# Altium schematic sheet [2] MCU.SchDoc
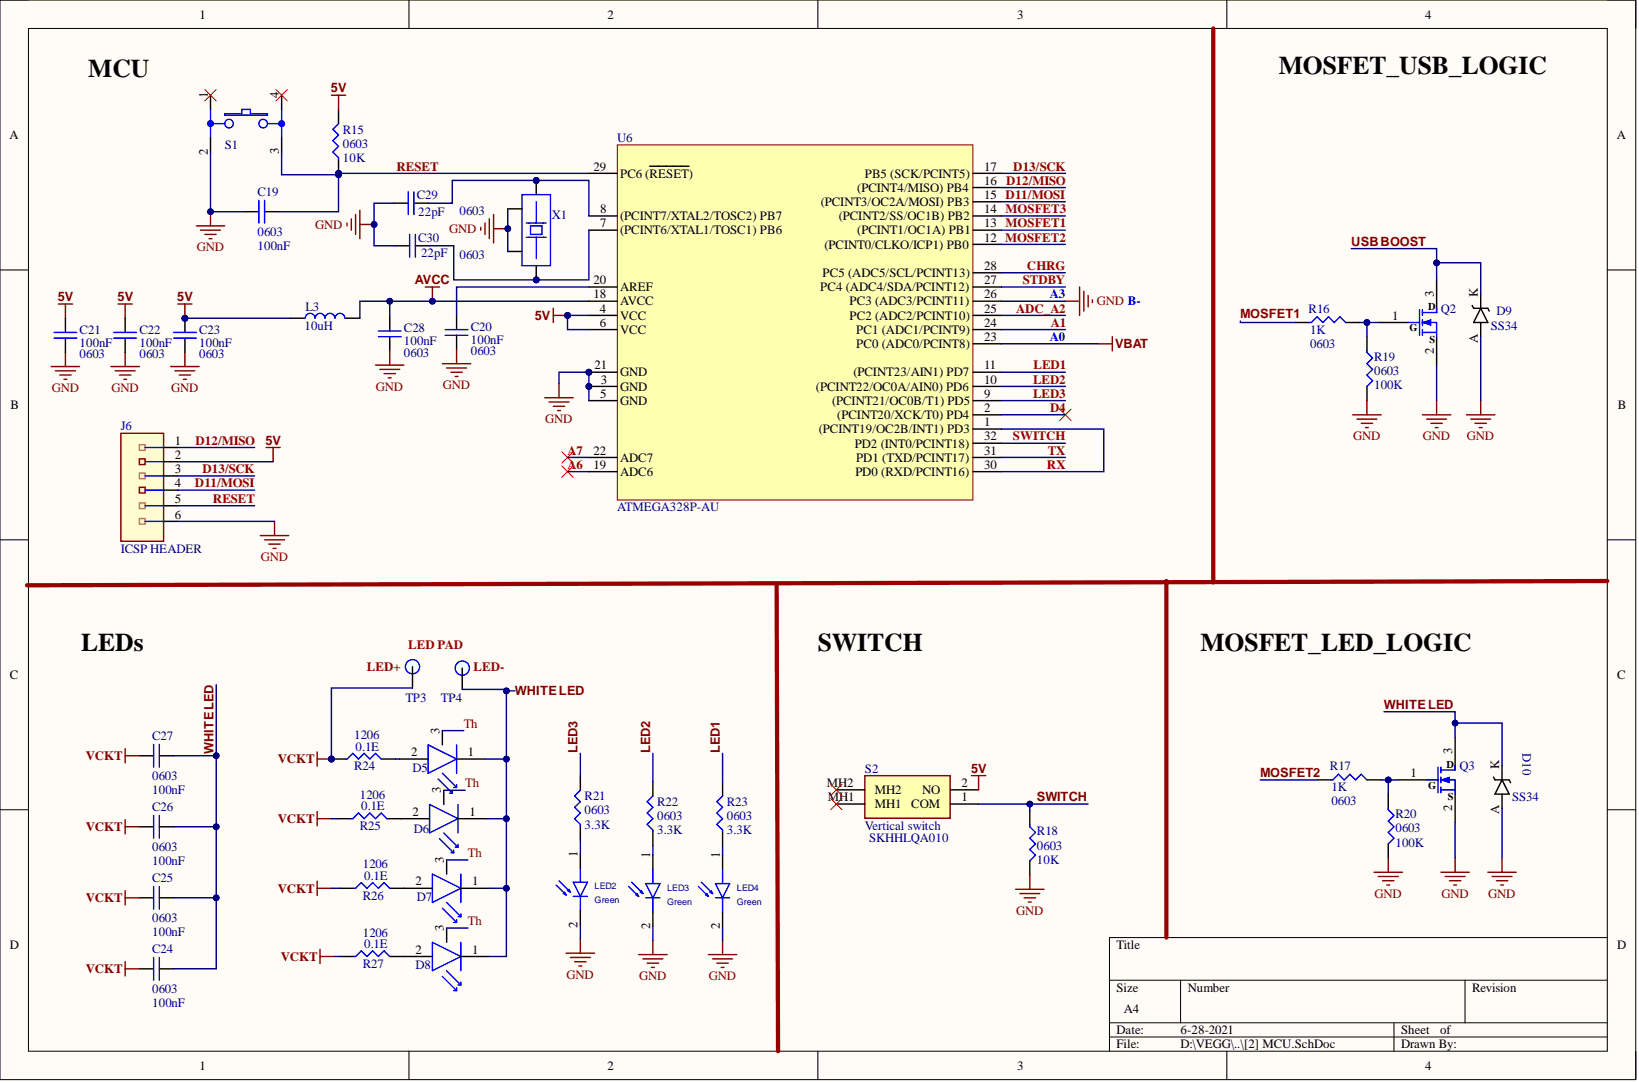
[diagram p0: [2] MCU.SchDoc]

## Parts
C19  value 100nF  Case/Package: 0603  [CAPC0603]  (hidden comment: Cap)
C20  value 100nF  Case/Package: 0603  [RESC0603]  (hidden comment: Cap)
C21  value 100nF  Case/Package: 0603  [RESC0603]  (hidden comment: Cap)
C22  value 100nF  Case/Package: 0603  [RESC0603]  (hidden comment: Cap)
C23  value 100nF  Case/Package: 0603  [CAPC0603]  (hidden comment: Cap)
C24  value 100nF  Case/Package: 0603  [CAPC0603]  (hidden comment: Cap)
C25  value 100nF  Case/Package: 0603  [CAPC0603]  (hidden comment: Cap)
C26  value 100nF  Case/Package: 0603  [CAPC0603]  (hidden comment: Cap)
C27  Case/Package: 0603  [CAPC0603]  (hidden comment: Cap)
C28  value 100nF  Case/Package: 0603  [CAPC0603]  (hidden comment: Cap)
C29  value 22pF  [CAPC0603]  (hidden comment: Cap)
C30  value 22pF  [CAPC0603]  (hidden comment: Cap)
D5  UF4007  [1W POWER LED]  (value not drawn)
D6  UF4007  [1W POWER LED]  (value not drawn)
D7  UF4007  [1W POWER LED]  (value not drawn)
D8  UF4007  [1W POWER LED]  (value not drawn)
D9  SS34  [SMA]
D10  SS34  [SMA]
J6  ICSP HEADER  [Header_2x3_R]
L3  value 10uH  [l0805]  (hidden comment: Inductor)
LED2  Green  [LED-1 - HORIZONTAL_2]
LED3  Green  [LED-1 - HORIZONTAL_2]
LED4  Green  [LED-1 - HORIZONTAL_2]
Q2  MOSFET-N Si2302CDS  [SOT95P240X115-3N]  (value not drawn)
Q3  MOSFET-N Si2302CDS  [SOT95P240X115-3N]  (value not drawn)
R15  value 10K  Case/Package: 0603  [RESC0603]  (hidden comment: Res)
R16  value 1K  Case/Package: 0603  [RESC0603]  (hidden comment: Res)
R17  value 1K  Case/Package: 0603  [RESC0603]  (hidden comment: Res)
R18  value 10K  Case/Package: 0603  [RESC0603]  (hidden comment: Res)
R19  value 100K  Case/Package: 0603  [RESC0603]  (hidden comment: Res)
R20  value 100K  Case/Package: 0603  [RESC0603]  (hidden comment: Res)
R21  value 3.3K  Case/Package: 0603  [RESC0603]  (hidden comment: Res)
R22  value 3.3K  Case/Package: 0603  [RESC0603]  (hidden comment: Res)
R23  value 3.3K  Case/Package: 0603  [RESC0603]  (hidden comment: Res)
R24  value 0.1E  Case/Package: 1206  [resc1206]  (hidden comment: Res)
R25  value 0.1E  Case/Package: 1206  [resc1206]  (hidden comment: Res)
R26  value 0.1E  Case/Package: 1206  [resc1206]  (hidden comment: Res)
R27  value 0.1E  Case/Package: 1206  [resc1206]  (hidden comment: Res)
S1  SW_TC_SPST_PUSH  [Tactile Switch, 4.5x4.5x5mm]  (value not drawn)
S2  Vertical switch  Manufacturer Part Number: SKHHLQA010  [Vertical switch]
TP3  TP  [TP-1]  (no BOM)
TP4  TP  [TP-1]  (no BOM)
U6  ATMEGA328P-AU  [TQFP-32_7x7x08P]
X1  XXDPBISANF-16.000000  [SMD-2520]  (value not drawn)

## Nets
5V: C21.1 C22.1 C23.1 J6.2 L3.1 R15.2 S2.2(NO) U6.4(VCC) U6.6(VCC)
AVCC: C28.1 L3.2 U6.18(AVCC)
GND: C19.1 C20.2 C21.2 C22.2 C23.2 C28.2 C29.2 C30.1 D9.A D10.A J6.6 LED2.2 LED3.2 LED4.2 Q2.2 Q3.2 R18.2 R19.2 R20.2 S1.2 U6.3(GND) U6.5(GND) U6.21(GND) U6.26(PC3_(ADC3/PCINT11)) X1.2 X1.4
VBAT: U6.23(PC0_(ADC0/PCINT8))
VCKT: C24.1 C25.1 C26.1 C27.1 R24.1 R25.1 R26.1 R27.1 TP3.1
A1: U6.24(PC1_(ADC1/PCINT9))
A6: U6.19(ADC6)
A7: U6.22(ADC7)
ADC_A2: U6.25(PC2_(ADC2/PCINT10))
CHRG: U6.28(PC5_(ADC5/SCL/PCINT13))
D4: U6.2((PCINT20/XCK/T0)_PD4)
D11/MOSI: J6.4 U6.15((PCINT3/OC2A/MOSI)_PB3)
D12/MISO: J6.1 U6.16((PCINT4/MISO)_PB4)
D13/SCK: J6.3 U6.17(PB5_(SCK/PCINT5))
LED1: R23.2 U6.11((PCINT23/AIN1)_PD7)
LED2: R22.2 U6.10((PCINT22/OC0A/AIN0)_PD6)
LED3: R21.2 U6.9((PCINT21/OC0B/T1)_PD5)
MOSFET1: R16.1 U6.13((PCINT1/OC1A)_PB1)
MOSFET2: R17.1 U6.12((PCINT0/CLKO/ICP1)_PB0)
MOSFET3: U6.14((PCINT2/SS/OC1B)_PB2)
RESET: C19.2 J6.5 R15.1 S1.3 U6.29(PC6_(RESET))
RX: U6.1((PCINT19/OC2B/INT1)_PD3) U6.30(PD0_(RXD/PCINT16))
STDBY: U6.27(PC4_(ADC4/SDA/PCINT12))
SWITCH: R18.1 S2.1(COM) U6.32(PD2_(INT0/PCINT18))
Th: D5.3 D6.3 D7.3 D8.3
TX: U6.31(PD1_(TXD/PCINT17))
USB BOOST: D9.K Q2.3
WHITE LED: C24.2 C25.2 C26.2 C27.2 D5.1 D6.1 D7.1 D8.1 D10.K Q3.3 TP4.1
NetC20_1: C20.1 U6.20(AREF)
NetC29_1: C29.1 U6.8((PCINT7/XTAL2/TOSC2)_PB7) X1.1
NetC30_2: C30.2 U6.7((PCINT6/XTAL1/TOSC1)_PB6) X1.3
NetD5_2: D5.2 R24.2
NetD6_2: D6.2 R25.2
NetD7_2: D7.2 R26.2
NetD8_2: D8.2 R27.2
NetLED2_1: LED2.1 R21.1
NetLED3_1: LED3.1 R22.1
NetLED4_1: LED4.1 R23.1
NetQ2_1: Q2.1 R16.2 R19.1
NetQ3_1: Q3.1 R17.2 R20.1

Not connected: S1 1 4; S2 MH1 MH2

## Library data of the parts
C19-C28 = Cap: Ceramic Capacitor,100nF,10V,0603; Manufacturer Part Number: CC0603KRX7R6BB104; Component Kind: Standard; ComponentLink1Description: http://www.farnell.com/datasheets/2739827.pdf?src-supplier=Element14; ComponentLink1URL: http://www.farnell.com/datasheets/2739827.pdf?src-supplier=Element14; ComponentLink2Description: http://www.farnell.com/datasheets/40889.pdf?src-supplier=Element14; ComponentLink2URL: http://www.farnell.com/datasheets/40889.pdf?src-supplier=Element14; Library Name: CUSTOM TNY290PG.SchLib; Signal Integrity: Cap Pol; Simulation: CAP
  C27: Value: 100nF
C29 C30 = Cap: Ceramic Capacitor,22pF,50V,0603; Manufacturer Part Number: FN18N220J500PSG; Case/Package: 0603; Component Kind: Standard; ComponentLink1Description: http://www.farnell.com/datasheets/2739827.pdf?src-supplier=Element14; ComponentLink1URL: http://www.farnell.com/datasheets/2739827.pdf?src-supplier=Element14; ComponentLink2Description: http://www.farnell.com/datasheets/40889.pdf?src-supplier=Element14; ComponentLink2URL: http://www.farnell.com/datasheets/40889.pdf?src-supplier=Element14; Library Name: CUSTOM TNY290PG.SchLib; Signal Integrity: Cap Pol; Simulation: CAP
D5-D8 = UF4007: Led Zpower Warm White 3000K 2SMD; Manufacturer 1: Seoul Semiconductor; Manufacturer Part Number: N42180-06-S2; Forward Voltage: 3.1V; Luminous Flux: 65lm; Max Current Rating: 800mA; Test Current: 350mA; Thermal Resistance of Package: 8.5°C/W; Viewing Angle: 126°; Case/Package: SMD/SMT; Category: LEDs; Color: White; Component Kind: Standard; ComponentLink1Description: https://datasheet.ciiva.com/29560/x42180-070-29560315.pdf?src-supplier=Digi-Key; ComponentLink1URL: https://datasheet.ciiva.com/29560/x42180-070-29560315.pdf?src-supplier=Digi-Key; ComponentLink2Description: https://datasheet.ciiva.com/29560/x42180-070-29560316.pdf?src-supplier=Digi-Key; ComponentLink2URL: https://datasheet.ciiva.com/29560/x42180-070-29560316.pdf?src-supplier=Digi-Key; Correlated Color Temperature (CCT): 3000K; Library Name: CUSTOM TNY290PG.SchLib; Mount: Surface Mount; Package Quantity: 500; Packaging: Tape and Reel; Pricing 1: 1=2.54000, 10=2.28800, 100=2.14400 (USD); RoHS: Yes; Stock 1: 29310; Supplier 1: Digi-Key; Supplier Part Number 1: N42180-06-S2CT-ND
D9 D10 = SS34: 40V 3A 550mV @ 3A SMA Schottky Barrier Diodes (SBD) RoHS; Case/Package: SMA; Component Kind: Standard; ComponentLink1Description: https://datasheet.ciiva.com/30478/0900766b812769b5-30478676.pdf?src-supplier=RSComponents; ComponentLink1URL: https://datasheet.ciiva.com/30478/0900766b812769b5-30478676.pdf?src-supplier=RSComponents; ComponentLink2Description: https://datasheet.ciiva.com/25958/esd9x3-3st5g-d-25958458.pdf?src-supplier=Avnet+Europe; ComponentLink2URL: https://datasheet.ciiva.com/25958/esd9x3-3st5g-d-25958458.pdf?src-supplier=Avnet+Europe; ComponentLink3Description: https://datasheet.ciiva.com/1219/1748783-1219324.pdf?src-supplier=Newark; ComponentLink3URL: https://datasheet.ciiva.com/1219/1748783-1219324.pdf?src-supplier=Newark; ComponentLink4Description: https://datasheet.ciiva.com/3304/esd9x3-3st5g-d-3304012.pdf?src-supplier=Avnet; ComponentLink4URL: https://datasheet.ciiva.com/3304/esd9x3-3st5g-d-3304012.pdf?src-supplier=Avnet; ComponentLink5Description: https://datasheet.ciiva.com/339/esd9x3-3st5g-d-339709.pdf?src-supplier=Digi-Key; ComponentLink5URL: https://datasheet.ciiva.com/339/esd9x3-3st5g-d-339709.pdf?src-supplier=Digi-Key; ComponentLink6Description: https://datasheet.ciiva.com/16215/esd9x3-3st5g-d-16215149.pdf?src-supplier=Future+Electronics; ComponentLink6URL: https://datasheet.ciiva.com/16215/esd9x3-3st5g-d-16215149.pdf?src-supplier=Future+Electronics; ComponentLink7Description: https://datasheet.ciiva.com/339/esd9x3-3st5g-d-339710.pdf?src-supplier=Digi-Key; ComponentLink7URL: https://datasheet.ciiva.com/339/esd9x3-3st5g-d-339710.pdf?src-supplier=Digi-Key; ComponentLink8Description: https://datasheet.ciiva.com/339/esd9x3-3st5g-d-339711.pdf?src-supplier=Digi-Key; ComponentLink8URL: https://datasheet.ciiva.com/339/esd9x3-3st5g-d-339711.pdf?src-supplier=Digi-Key; Library Name: CUSTOM TNY290PG.SchLib; Manufacturer Part Number: SS34; Value: SS34
J6 = HEADER 6: 6P_2 Row(2x3) P=2.54mm Straight Pin Header; Manufacturer Part Number: PZ254V-12-6P; Case/Package: TH 2.54MM; Component Kind: Standard; Library Name: CUSTOM TNY290PG.SchLib
L3 = Inductor: 10uH 100mA 0805 Chip ferrite Inductor RoHS; Manufacturer Part Number: MGFL2012F100MT-LF; Case/Package: 0805; Component Kind: Standard; Library Name: CUSTOM TNY290PG.SchLib
LED2-LED4 = LED2: LED, Green, Untinted, Diffused; Manufacturer Part Number: KP DELPS1.FP-UGVI-34-Z555; Case/Package: TH; Component Kind: Standard; Stock 1: 0; Supplier 1: Mouser; Supplier Part Number 1: 720-KPDELPS1FPA3998
Q2 Q3 = MOSFET-N Si2302CDS: N-Channel 20V 3A 1.25W (Ta) Surface Mount SOT-23; Manufacturer: MCC; Manufacturer Part Number: SI2302-TP; Drain to Source Resistance: 110mR; Gate to Source Voltage (Vgs): 8V; Input Capacitance: 237pF; Number of Elements: 1; Rds On Max: 72mR; Case/Package: SOT-23; Component Kind: Standard; ComponentLink1Description: http://www.vishay.com/docs/68645/si2302cd.pdf?src-supplier=Avnet; ComponentLink1URL: http://www.vishay.com/docs/68645/si2302cd.pdf?src-supplier=Avnet; ComponentLink2Description: http://www.farnell.com/datasheets/1719572.pdf?src-supplier=Element14; ComponentLink2URL: http://www.farnell.com/datasheets/1719572.pdf?src-supplier=Element14; ComponentLink3Description: http://www.vishay.com/docs/68645/si2302cd.pdf?src-supplier=Future+Electronics; ComponentLink3URL: http://www.vishay.com/docs/68645/si2302cd.pdf?src-supplier=Future+Electronics; ComponentLink4Description: http://www.vishay.com/docs/68645/si2302cds.pdf?src-supplier=Digi-Key; ComponentLink4URL: http://www.vishay.com/docs/68645/si2302cds.pdf?src-supplier=Digi-Key; ComponentLink5Description: http://www.mccsemi.com/up_pdf/SI2302(SOT-23).pdf?src-supplier=Digi-Key; ComponentLink5URL: http://www.mccsemi.com/up_pdf/SI2302(SOT-23).pdf?src-supplier=Digi-Key; Continuous Drain Current (ID): 3A; Drain to Source Breakdown Voltage: 20V; Drain to Source Voltage (Vdss): 20V; Lead Free: Lead Free; Library Name: Miscellaneous Devices.SchLib; Max Operating Temperature: 150°C; Max Power Dissipation: 1.25W; Min Operating Temperature: -55°C; Mount: Surface Mount; Number of Pins: 3; Package Quantity: 3000; Packaging: Tape and Reel; Power Dissipation: 1.25W; Pricing 1: 1=0.45, 10=0.386, 100=0.288, 500=0.226, 1000=0.175, 3000=0.145 (USD); Simulation: NMOS; Stock 1: 29970; Supplier 1: Mouser; Supplier Part Number 1: 833-SI2302-TP
R15 R18 = Res: Thick Film Resistor -  10Kohm, 1%, 0603; Manufacturer Part Number: RK73B1JTTD103J; Component Kind: Standard; Library Name: CUSTOM TNY290PG.SchLib
R16 R17 = Res: Thick Film Resistor -  1Kohm, 1%, 0603; Manufacturer Part Number: RS-03K1001FT; Component Kind: Standard; Library Name: CUSTOM TNY290PG.SchLib
R19 R20 = Res: Thick Film Resistor -  100Kohm, 1%, 0603; Manufacturer Part Number: RS-03K1001FT; Component Kind: Standard; Library Name: CUSTOM TNY290PG.SchLib
R21-R23 = Res: Thick Film Resistor -  3.3Kohm, 1%, 0603; Manufacturer Part Number: RS-03K3301FT; Component Kind: Standard; Library Name: CUSTOM TNY290PG.SchLib
R24-R27 = Res: Thick Film Resistor - 0.1ohm, 1%, 0.25W, 1206; Manufacturer Part Number: CR1206FR100P05R; Component Kind: Standard; Library Name: CUSTOM TNY290PG.SchLib
S1 = SW_TC_SPST_PUSH: SPST 50mA @ 12VDC Top Actuated Round Button 160gf 4.5*4.5*3.8 Tactile Switch; Manufacturer Part Number: UK-B0206-G3.8-160-JZ; Case/Package: 4.5X4.5X5mm_SMD; Component Kind: Standard; Library Name: CUSTOM TNY290PG.SchLib
S2 = Vertical switch: SPST 50mA @ 12VDC Side operation Round Button Through Hole Tactile Switches RoHS; Manufacturer: Alps Electric; Contact Resistance: 100mR; Electrical Life: 1000000Cycles; Illumination Type: Illuminated; Max Voltage Rating (DC): 12V; Operating Force: 0.98N; Case/Package: TH; Category: Tactile Switches; Color: Black; Component Kind: Standard; ComponentLink1Description: https://datasheet.ciiva.com/6797/1582312-6797697.pdf?src-supplier=Newark; ComponentLink1URL: https://datasheet.ciiva.com/6797/1582312-6797697.pdf?src-supplier=Newark; ComponentLink2Description: https://datasheet.ciiva.com/25620/0900766b810d5f21-25620205.pdf?src-supplier=RSComponents; ComponentLink2URL: https://datasheet.ciiva.com/25620/0900766b810d5f21-25620205.pdf?src-supplier=RSComponents; ComponentLink3Description: https://datasheet.ciiva.com/26906/getdatasheetpartid-652171-26906222.pdf?src-supplier=Verical; ComponentLink3URL: https://datasheet.ciiva.com/26906/getdatasheetpartid-652171-26906222.pdf?src-supplier=Verical; ComponentLink4Description: https://datasheet.ciiva.com/16156/skhh-16156038.pdf?src-supplier=Onlinecomponents.com; ComponentLink4URL: https://datasheet.ciiva.com/16156/skhh-16156038.pdf?src-supplier=Onlinecomponents.com; ComponentLink5Description: https://datasheet.ciiva.com/14142/03564812-14142487.pdf?src-supplier=Distrelec; ComponentLink5URL: https://datasheet.ciiva.com/14142/03564812-14142487.pdf?src-supplier=Distrelec; Contact Current Rating: 50mA; Current Rating: 50mA; Depth: 12.35mm; Lead Free: Lead Free; Length: 7.5mm; Library Name: CUSTOM TNY290PG.SchLib; Max Current Rating: 50mA; Max Operating Temperature: 85°C; Mechanical Life: 1000000Cycles; Min Operating Temperature: -20°C; Mount: Through Hole; Orientation: Right Angle; Package Quantity: 1000; Packaging: Bulk; Pricing 1: 1000=0.25049, 1002=0.24353, 2002=0.23695, 5000=0.23071, 10000=0.22479 (USD); Radiation Hardening: No; RoHS: Yes; Stock 1: 991; Supplier 1: Avnet; Supplier Part Number 1: SKHHLQA010; Termination: PC Pins; Throw Configuration: SPST; Voltage Rating (DC): 12V
U6 = ATMEGA328P-AU: MICROCHIP - ATMEGA328P-AU. - MICROCONTROLLER MCU, 8 BIT, ATMEGA, 20MHZ, TQFP-32; Manufacturer 1: Microchip / Atmel; Core Architecture: AVR; Data Bus Width: 8b; Interface: I2C,SPI,UART,USART,2-Wire; Max Supply Voltage: 5.5V; Memory Size: 32KB; Memory Type: FLASH; Min Supply Voltage: 1.8V; Number of ADC Channels: 8; Number of I2C Channels: 1; Number of I/Os: 23; Number of PWM Channels: 6; Number of Timers/Counters: 3; Oscillator Type: Internal; Peripherals: Brown-out Detect/Reset,POR,PWM,WDT; RAM Size: 2KB; Watchdog Timer: Yes; Case/Package: TQFP-32; Category: Microcontrollers; Component Kind: Standard; ComponentLink1Description: https://datasheet.ciiva.com/1166/doc8161-1166860.pdf?src-supplier=Newark; ComponentLink1URL: https://datasheet.ciiva.com/1166/doc8161-1166860.pdf?src-supplier=Newark; ComponentLink2Description: https://datasheet.ciiva.com/29119/155196-da-01-en-microcontr-atmega328p-au-8bit-tqfp-32-29119594.pdf?src-supplier=Conrad; ComponentLink2URL: https://datasheet.ciiva.com/29119/155196-da-01-en-microcontr-atmega328p-au-8bit-tqfp-32-29119594.pdf?src-supplier=Conrad; ComponentLink3Description: https://datasheet.ciiva.com/15213/getdatasheetpartid-365828-15213131.pdf?src-supplier=Verical; ComponentLink3URL: https://datasheet.ciiva.com/15213/getdatasheetpartid-365828-15213131.pdf?src-supplier=Verical; ComponentLink4Description: https://datasheet.ciiva.com/1157/1693866-1157693.pdf?src-supplier=Element14; ComponentLink4URL: https://datasheet.ciiva.com/1157/1693866-1157693.pdf?src-supplier=Element14; Frequency: 20MHz; Height: 1.05mm; Lead Free: Lead Free; Length: 7mm; Library Name: CUSTOM TNY290PG.SchLib; Manufacturer Part Number: ATMEGA328P-AU; Max Frequency: 20MHz; Max Operating Temperature: 85°C; Min Operating Temperature: -40°C; Mount: Surface Mount; Number of Pins: 32; Number of Programmable I/O: 23; Number of SPI Channels: 1; Package Quantity: 250; Packaging: Tray; Pricing 1: 1=2.01000, 25=1.84000, 100=1.67000 (USD); Radiation Hardening: No; REACH SVHC: No SVHC; RoHS: Yes; Stock 1: 4578; Supplier 1: Digi-Key; Supplier Part Number 1: ATMEGA328P-AU-ND; Width: 7mm
X1 = CRYSTAL_1: 16MHz ±15ppm Crystal 12pF 80 Ohms 4-SMD, No Lead; Case/Package: SMD-2520_4P; Component Kind: Standard; ComponentLink1Description: https://www.verical.com/server-webapp/html/getDatasheet?partID=757227&src-supplier=Verical; ComponentLink1URL: https://www.verical.com/server-webapp/html/getDatasheet?partID=757227&src-supplier=Verical; ComponentLink2Description: http://www.txccrystal.com/images/pdf/7m-accuracy.pdf?src-supplier=Digi-Key; ComponentLink2URL: http://www.txccrystal.com/images/pdf/7m-accuracy.pdf?src-supplier=Digi-Key; ComponentLink3Description: http://www.farnell.com/datasheets/1794111.pdf?src-supplier=Element14; ComponentLink3URL: http://www.farnell.com/datasheets/1794111.pdf?src-supplier=Element14; ComponentLink4Description: http://www.mouser.com/ds/2/417/7m-11184.pdf?src-supplier=Mouser; ComponentLink4URL: http://www.mouser.com/ds/2/417/7m-11184.pdf?src-supplier=Mouser; Library Name: CUSTOM TNY290PG.SchLib; Manufacturer Part Number: XXDPBISANF-16.000000; Value: 16Mhz
TP3 TP4 = TP: Description: TP; Library Name: CUSTOM TNY290PG.SchLib
